# Altium schematic sheet rj45.SchDoc(rj45)
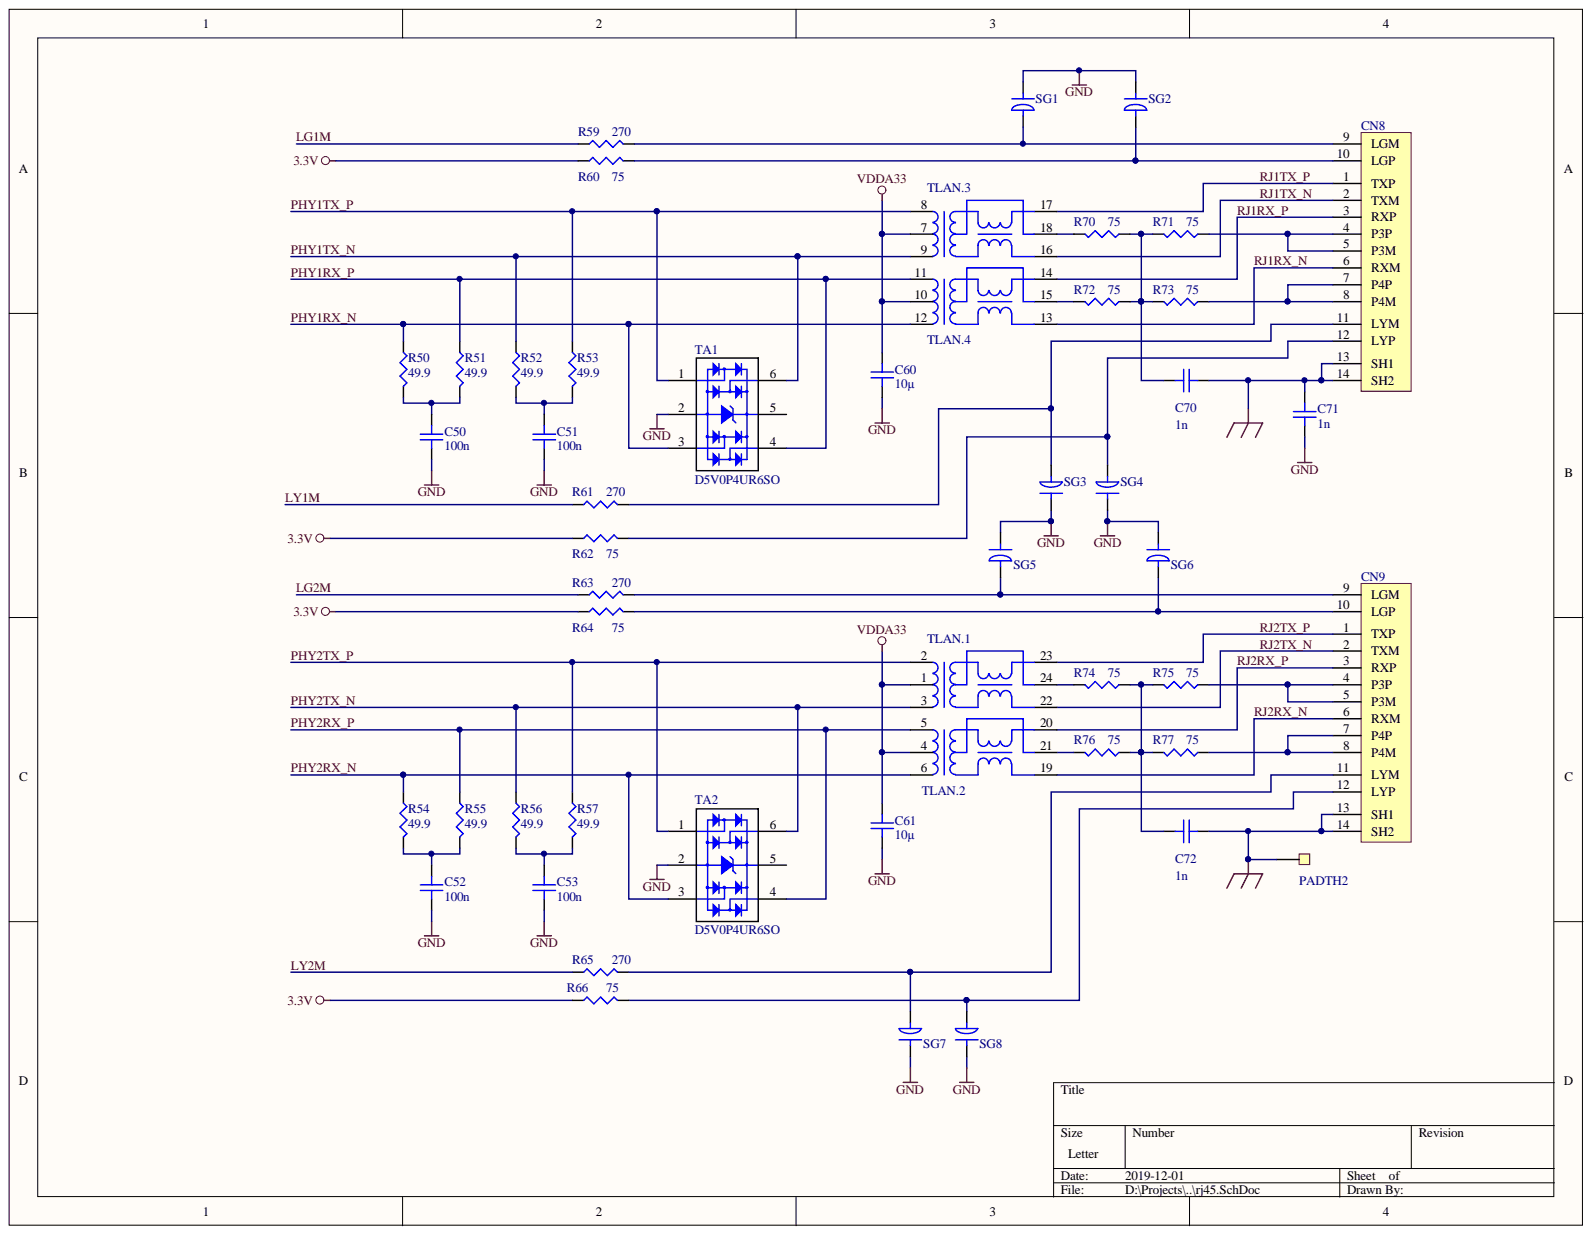
[diagram p0: rj45.SchDoc]

## Parts
C50  100n  [0402_C]
C51  100n  [0402_C]
C52  100n  [0402_C]
C53  100n  [0402_C]
C60  10µ  [0603_C]
C61  10µ  [0603_C]
C70  1n  [1206_C]
C71  1n  [1206_C]
C72  1n  [1206_C]
CN8  (no value)  [RJ45-1]
CN9  (no value)  [RJ45-1]
PADTH2  PAD TH  [PAD TH HD3 OD5.6 P]  (no BOM)
R50  49.9  [0402_R]
R51  49.9  [0402_R]
R52  49.9  [0402_R]
R53  49.9  [0402_R]
R54  49.9  [0402_R]
R55  49.9  [0402_R]
R56  49.9  [0402_R]
R57  49.9  [0402_R]
R59  270  [0603_R]
R60  75  [0603_R]
R61  270  [0603_R]
R62  75  [0603_R]
R63  270  [0603_R]
R64  75  [0603_R]
R65  270  [0603_R]
R66  75  [0603_R]
R70  75  [0603_R]
R71  75  [0603_R]
R72  75  [0603_R]
R73  75  [0603_R]
R74  75  [0603_R]
R75  75  [0603_R]
R76  75  [0603_R]
R77  75  [0603_R]
SG1  SGPCB  [SGPCB-A015]  (value not drawn)  (no BOM)
SG2  SGPCB  [SGPCB-A015]  (value not drawn)  (no BOM)
SG3  SGPCB  [SGPCB-A015]  (value not drawn)  (no BOM)
SG4  SGPCB  [SGPCB-A015]  (value not drawn)  (no BOM)
SG5  SGPCB  [SGPCB-A015]  (value not drawn)  (no BOM)
SG6  SGPCB  [SGPCB-A015]  (value not drawn)  (no BOM)
SG7  SGPCB  [SGPCB-A015]  (value not drawn)  (no BOM)
SG8  SGPCB  [SGPCB-A015]  (value not drawn)  (no BOM)
TA1  D5V0P4UR6SO  [SOT26]
TA2  D5V0P4UR6SO  [SOT26]
TLAN  (no value)  [TR-LAN-GBIT-1]  (parts .1,.2,.3,.4)

## Nets
3.3V: R60.1 R62.1 R64.1 R66.1
CHASSIS: C70.2 C71.1 C72.2 CN8.13(SH1) CN8.14(SH2) CN9.13(SH1) CN9.14(SH2) PADTH2.1
GND: C50.1 C51.1 C52.1 C53.1 C60.1 C61.1 C71.2 SG1.1 SG2.1 SG3.1 SG4.1 SG5.1 SG6.1 SG7.1 SG8.1 TA1.2 TA2.2
VDDA33: C60.2 C61.2 TLAN.1 TLAN.4 TLAN.7 TLAN.10
LG1M: R59.1
LG2M: R63.1
LY1M: R61.1
LY2M: R65.1
PHY1RX_N: R50.1 TA1.3 TLAN.12
PHY1RX_P: R51.1 TA1.4 TLAN.11
PHY1TX_N: R52.1 TA1.6 TLAN.9
PHY1TX_P: R53.1 TA1.1 TLAN.8
PHY2RX_N: R54.1 TA2.3 TLAN.6
PHY2RX_P: R55.1 TA2.4 TLAN.5
PHY2TX_N: R56.1 TA2.6 TLAN.3
PHY2TX_P: R57.1 TA2.1 TLAN.2
RJ1RX_N: CN8.6(RXM) TLAN.13
RJ1RX_P: CN8.3(RXP) TLAN.14
RJ1TX_N: CN8.2(TXM) TLAN.16
RJ1TX_P: CN8.1(TXP) TLAN.17
RJ2RX_N: CN9.6(RXM) TLAN.19
RJ2RX_P: CN9.3(RXP) TLAN.20
RJ2TX_N: CN9.2(TXM) TLAN.22
RJ2TX_P: CN9.1(TXP) TLAN.23
NetC50_2: C50.2 R50.2 R51.2
NetC51_2: C51.2 R52.2 R53.2
NetC52_2: C52.2 R54.2 R55.2
NetC53_2: C53.2 R56.2 R57.2
NetC70_1: C70.1 R70.1 R71.2 R72.1 R73.2
NetC72_1: C72.1 R74.1 R75.2 R76.1 R77.2
NetCN8_4: CN8.4(P3P) CN8.5(P3M) R71.1
NetCN8_7: CN8.7(P4P) CN8.8(P4M) R73.1
NetCN8_9: CN8.9(LGM) R59.2 SG1.2
NetCN8_10: CN8.10(LGP) R60.2 SG2.2
NetCN8_11: CN8.11(LYM) R61.2 SG3.2
NetCN8_12: CN8.12(LYP) R62.2 SG4.2
NetCN9_4: CN9.4(P3P) CN9.5(P3M) R75.1
NetCN9_7: CN9.7(P4P) CN9.8(P4M) R77.1
NetCN9_9: CN9.9(LGM) R63.2 SG5.2
NetCN9_10: CN9.10(LGP) R64.2 SG6.2
NetCN9_11: CN9.11(LYM) R65.2 SG7.2
NetCN9_12: CN9.12(LYP) R66.2 SG8.2
NetR70_2: R70.2 TLAN.18
NetR72_2: R72.2 TLAN.15
NetR74_2: R74.2 TLAN.24
NetR76_2: R76.2 TLAN.21

Not connected: TA1 5; TA2 5

## Library data of the parts
C50-C53 = Cap: CAP CER 0.1UF 16V X7R 0402; Manufacturer: YAGEO; Part Number: CC0402KRX7R7BB104; Component Kind: Standard; Library Name: SchLib.SchLib
C60 C61 = Cap: CAP CER 10UF 6.3V X5R 0603; Manufacturer: SAMSUNG E-M; Part Number: CL10A106MQ8NNNC; Component Kind: Standard; Library Name: SchLib.SchLib
C70-C72 = Cap: CAP CER 1000PF 2KV X7R 1206; Manufacturer: WALSIN TECH; Part Number: 1206B102K202CT; Component Kind: Standard; Library Name: SchLib.SchLib
CN8 CN9 = RJ45-R310: MOD JACK RJ45 2LED S4.2 SHLD; Manufacturer: LIJING ELECTRONIC; Part Number: RJ310-G21-200-0R; Component Kind: Standard; Library Name: SchLib.SchLib
PADTH2 = PAD: PAD TH HD3 OD5.6 P; Library Name: SchLib.SchLib
R50-R57 = Res: RES 49.9 OHM 1% 0.063W 0402; Manufacturer: YAGEO; Part Number: RC0402FR-0749R9L; Component Kind: Standard; Library Name: SchLib.SchLib
R59 R61 R63 R65 = Res: RES SMD 270 OHM 1% 1/10W 0603; Manufacturer: Yageo; Part Number: RC0603FR-07270RL; Component Kind: Standard; Library Name: SchLib.SchLib
R60 R62 R64 R66 R70-R77 = Res: RES 75 OHM 1% 0.1W 0603; Manufacturer: YAGEO; Part Number: RC0603FR-0775RL; Component Kind: Standard; Library Name: SchLib.SchLib
TA1 TA2 = D5V0P4UR6SO: TVS DIODE ARRAY 4CH 3.3V 6V SOT26; Manufacturer: DIODES INC; Component Kind: Standard; Library Name: SchLib.SchLib; Part Number: D5V0P4UR6SO
TLAN = TRFLAN24: TRF-LAN 350UH 1000GBIT SMT; Manufacturer: HULYN; Part Number: TGE-2401SR; Component Kind: Standard; Library Name: SchLib.SchLib
SG1-SG8 = SGPCB: Library Name: SchLib.SchLib
